# Altium schematic sheet Main.SchDoc
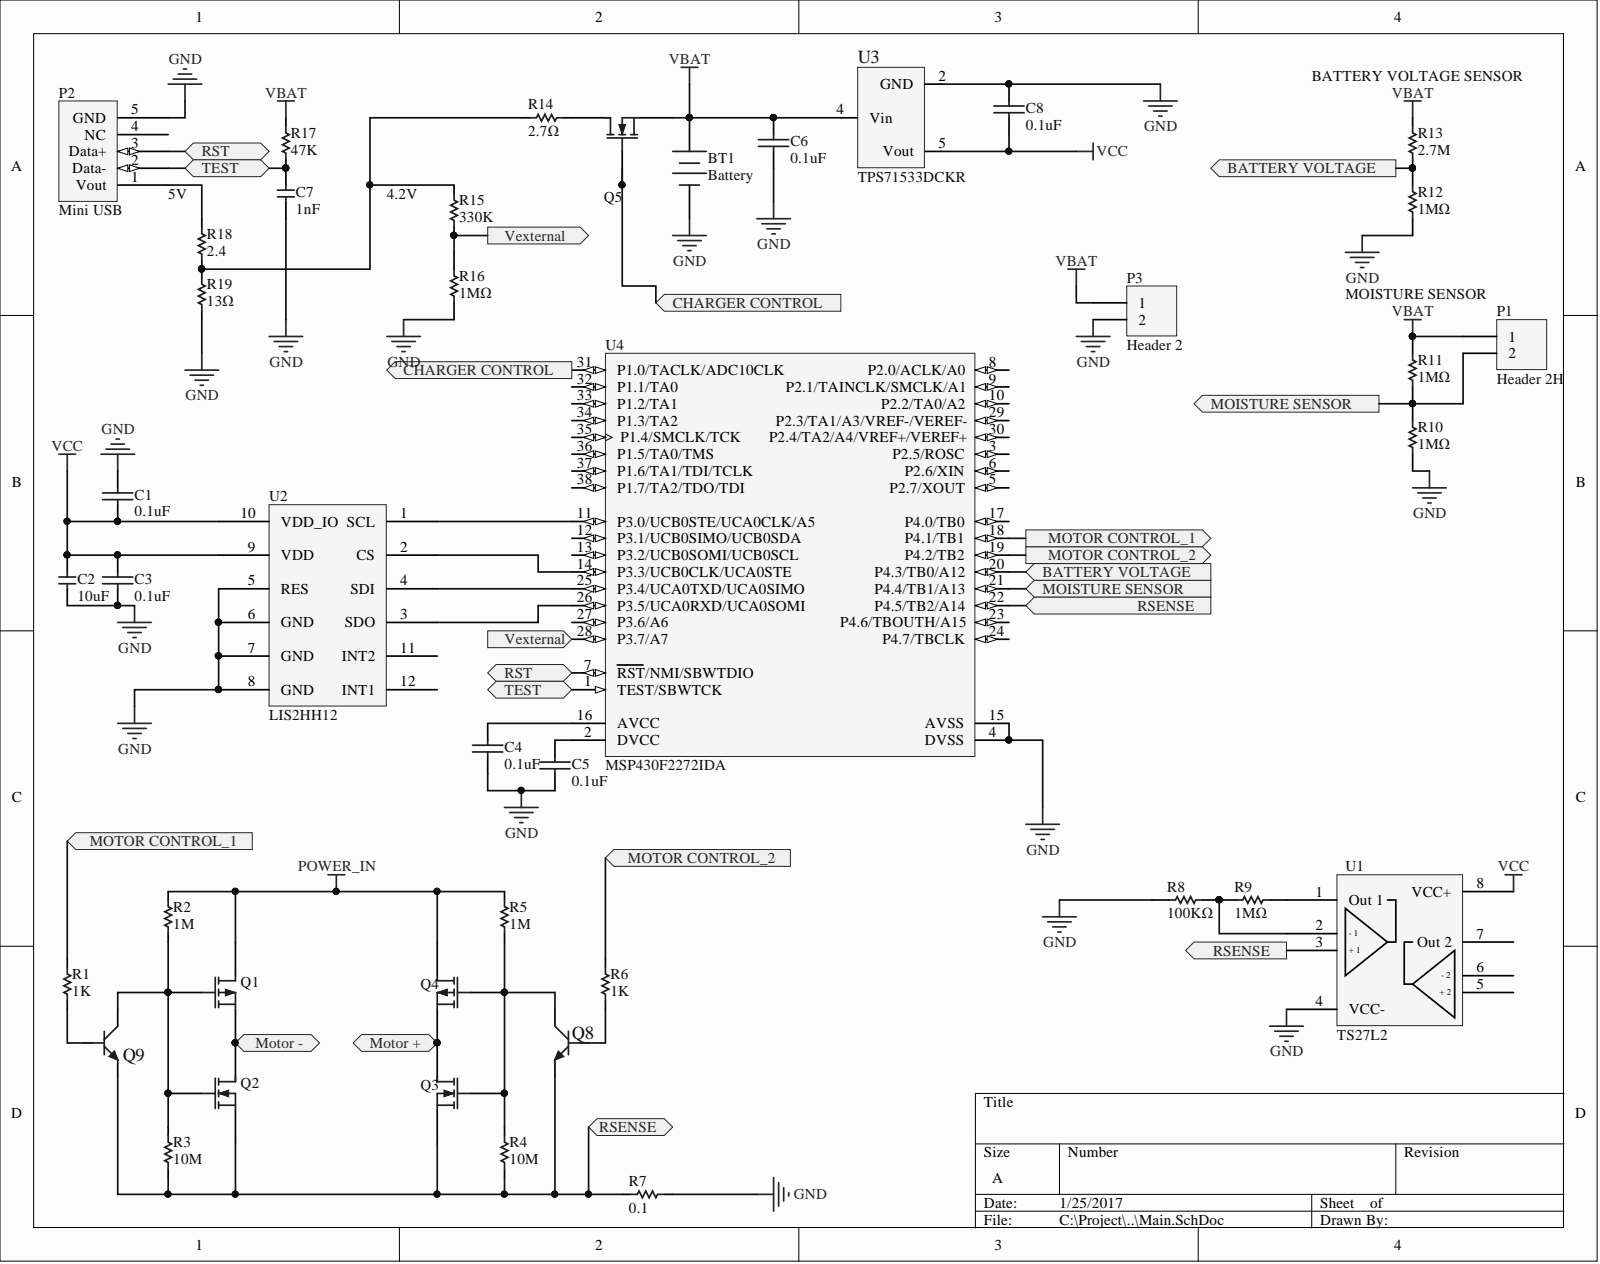
[diagram p0: Main.SchDoc]

## Parts
BT1  Battery
C1  0.1uF  [0603 Small]
C2  10uF  [0805 Small]
C3  0.1uF  [0603 Small]
C4  0.1uF  [0603 Small]
C5  0.1uF  [0603 Small]
C6  0.1uF  [0603 Small]
C7  1nF  [0402 Small]
C8  0.1uF  [0603 Small]
P1  Header 2H  [HDR1X2H]
P2  Mini USB  [Mini USB]
P3  Header 2  [BH123A]
Q1  MOSFET-P  [SOT23]  (value not drawn)
Q2  MOSFET-N  [SOT23]  (value not drawn)
Q3  MOSFET-N  [SOT23]  (value not drawn)
Q4  MOSFET-P  [SOT23]  (value not drawn)
Q5  MOSFET-N  [SOT23]  (value not drawn)
Q8  MMST2222A  [SOT-23N]  (value not drawn)
Q9  MMST2222A  [SOT-23N]  (value not drawn)
R1  1K  [0603 resistor]
R2  1M  [0603 resistor]
R3  10M  [0603 resistor]
R4  10M  [0603 resistor]
R5  1M  [0603 resistor]
R6  1K  [0603 resistor]
R7  0.1  [0603 resistor]
R8  100KΩ  [0603 Resistor]
R9  1MΩ  [0603 Resistor]
R10  1MΩ  [0603 Resistor]
R11  1MΩ  [0603 Resistor]
R12  1MΩ  [0603 Resistor]
R13  2.7M  [0402 Small]
R14  2.7Ω  [2010 resistor]
R15  330K  [0402 Resistor]
R16  1MΩ  [0603 Resistor]
R17  47K  [0402 Resistor]
R18  2.4  [0805 Small]
R19  13Ω  [2512 RESISTOR]
U1  TS27L2  [SOIC-8]
U2  LIS2HH12  [LIS2HH12]
U3  TPS71533DCKR  [SC70_5]
U4  MSP430F2272IDA  [MSP430F2272IDA]

## Ports
BATTERY VOLTAGE, CHARGER CONTROL, MOISTURE SENSOR, MOTOR +, MOTOR -, MOTOR CONTROL_1, MOTOR CONTROL_2, RSENSE, RST, TEST, VEXTERNAL

## Nets
GND: BT1.2 C1.1 C2.1 C3.2 C4.2 C5.2 C6.2 C7.1 C8.1 P2.5(GND) P3.2 R7.1 R8.1 R10.1 R12.1 R16.1 R19.1 U1.4(VCC-) U2.5(RES) U2.6(GND) U2.7(GND) U2.8(GND) U3.2 U4.4(DVSS) U4.15(AVSS)
POWER_IN: Q1.1 Q4.1 R2.1 R5.1
VBAT: BT1.1 C6.1 P1.1 P3.1 Q5.3 R11.2 R13.1 R17.1 U3.4
VCC: C1.2 C2.2 C3.1 C8.2 U1.8(VCC+) U2.9(VDD) U2.10(VDD_IO) U3.5
BATTERY VOLTAGE: R12.2 R13.2 U4.20(P4.3/TB0/A12) ; port BATTERY VOLTAGE
CHARGER CONTROL: Q5.2 U4.31(P1.0/TACLK/ADC10CLK) ; port CHARGER CONTROL
MOISTURE SENSOR: P1.2 R10.2 R11.1 U4.21(P4.4/TB1/A13) ; port MOISTURE SENSOR
MOTOR CONTROL_1: R1.2 U4.18(P4.1/TB1) ; port MOTOR CONTROL_1
MOTOR CONTROL_2: R6.2 U4.19(P4.2/TB2) ; port MOTOR CONTROL_2
RSENSE: Q2.3 Q3.3 Q8.E Q9.E R3.1 R4.1 R7.2 U1.3(+_1) U4.22(P4.5/TB2/A14) ; port RSENSE
RST: P2.3(Data+) U4.7(RST/NMI/SBWTDIO) ; port RST
TEST: C7.2 P2.2(Data-) R17.2 U4.1(TEST/SBWTCK) ; port TEST
NetC4_1: C4.1 U4.16(AVCC)
NetC5_1: C5.1 U4.2(DVCC)
NetP2_1: P2.1(Vout) R18.2
NetQ1_2: Q1.2 Q2.2 Q9.C R2.2 R3.2
NetQ1_3: Q1.3 Q2.1
NetQ3_1: Q3.1 Q4.3
NetQ3_2: Q3.2 Q4.2 Q8.C R4.2 R5.2
NetQ5_1: Q5.1 R14.1
NetQ8_B: Q8.B R6.1
NetQ9_B: Q9.B R1.1
NetR8_2: R8.2 R9.2 U1.2(-_1)
NetR9_1: R9.1 U1.1(Out_1)
NetR14_2: R14.2 R15.1 R18.1 R19.2
NetR15_2: R15.2 R16.2 U4.28(P3.7/A7) ; port VEXTERNAL
NetU2_1: U2.1(SCL) U4.11(P3.0/UCB0STE/UCA0CLK/A5)
NetU2_2: U2.2(CS) U4.14(P3.3/UCB0CLK/UCA0STE)
NetU2_3: U2.3(SDO) U4.26(P3.5/UCA0RXD/UCA0SOMI)
NetU2_4: U2.4(SDI) U4.25(P3.4/UCA0TXD/UCA0SIMO)

Not connected: P2 4(NC); U1 5(+_2) 6(-_2) 7(Out_2); U2 11(INT2) 12(INT1); U4 3(P2.5/ROSC) 5(P2.7/XOUT) 6(P2.6/XIN) 8(P2.0/ACLK/A0) 9(P2.1/TAINCLK/SMCLK/A1) 10(P2.2/TA0/A2) 12(P3.1/UCB0SIMO/UCB0SDA) 13(P3.2/UCB0SOMI/UCB0SCL) 17(P4.0/TB0) 23(P4.6/TBOUTH/A15) 24(P4.7/TBCLK) 27(P3.6/A6) 29(P2.3/TA1/A3/VREF-/VEREF-) 30(P2.4/TA2/A4/VREF+/VEREF+) 32(P1.1/TA0) 33(P1.2/TA1) 34(P1.3/TA2) 35(P1.4/SMCLK/TCK) 36(P1.5/TA0/TMS) 37(P1.6/TA1/TDI/TCLK) 38(P1.7/TA2/TDO/TDI)

## Library data of the parts
BT1 = Battery: Multicell Battery; Manufacture: Olight; Manufacturer#: ORB2-163P06; Component Kind: Standard; Cost: 7.5; LatestRevisionDate: 17-Jul-2002; LatestRevisionNote: Re-released for DXP Platform.; Library Name: Miscellaneous Devices.IntLib; PackageDescription: Battery; 2 Leads; PackageReference: BAT-2; Published: 24-Mar-1999; Publisher: Altium Limited
C1 C3-C6 C8 = CAP: CAP CER 0.1UF 50V 10% X7R 0603; Manufacturer: Murata Electronics; Manufacturer#: GRM188R71H104KA93J; Component Kind: Standard; Cost: 0.022; Library Name: sch protel.SCHLIB
C2 = Cap: CAP CER 10UF 6.3V X5R 0805; Manufacturer: Samsung Electro-Mechanical; Manufacturer#: CL21A106KQCLRNC; Component Kind: Standard; Cost: .01526; Signal Integrity: Cap; Simulation: CAP; Library Name: Curtis.SchLib
C7 = Cap: CAP CER 1000PF 6.3V X5R 0402; Manufacturer: Murata Electronics; Manufacturer#: GRM155R60J102KA01D; Component Kind: Standard; Cost: .0024; Signal Integrity: Cap; Simulation: CAP; Library Name: Curtis.SchLib
P1 = Header 2H: Header, 2-Pin, Right Angle; Component Kind: Standard; LatestRevisionDate: 17-Jul-2002; LatestRevisionNote: Re-released for DXP Platform.; Library Name: Miscellaneous Connectors.IntLib; Signal Integrity: Connector; Publisher: Altium Limited
P2 = Mini USB: CONN MINI USB RCPT RA TYPE B SMD; Manufacturer: EDAC Inc.; Manufacturer#: 690-005-299-043; Component Kind: Standard; Cost: 0.28050; Library Name: Curtis.SchLib
P3 = Header 2: CR123A Battery Holder; Manufacturer: Memory Protection Devices Inc; Component Kind: Standard; Cost: 0.65; Library Name: Miscellaneous Connectors.IntLib; Manufacturer#: BH123A
Q1 Q4 = MOSFET-P: MOSFET P-CH 20V 4.2A SOT23; Manufacturer: Diodes Incorporated; Manufacturer#: DMG2305UX-13; Component Kind: Standard; Cost: 0.0738; Library Name: Miscellaneous Devices.IntLib
Q2 Q3 Q5 = MOSFET-N: MOSFET N-CH 20V 2.3A SOT23; Manufacturer: Infineon Technologies; Manufacturer#: BSS806N H6327; Component Kind: Standard; Cost: 0.07392; Library Name: Miscellaneous Devices.IntLib
Q8 Q9 = NPN1: TRANSISTOR NPN GP 40V SOT23; Manufacturer: MICRO COMMERCIAL CO; Manufacturer#: MMBT2222A-TP; Component Kind: Standard; Cost: 0.0278; Library Name: Curtis.SchLib
R1 R6 = Resistor: RES SMD 1K OHM 5% 1/10W 060; Manufacturer: Yageo; Manufacturer#: RC0603JR-071KL; Component Kind: Standard; Cost: 0.0018; Library Name: Curtis.SchLib
R2 R5 = Resistor: RES SMD 1M OHM 5% 1/10W 0603; Manufacturer: Yageo; Manufacturer#: RC0603JR-071ML; Component Kind: Standard; Cost: 0.0018; Library Name: Curtis.SchLib
R3 R4 = Resistor: RES SMD 10M OHM 5% 1/10W 0603; Manufacturer: Yageo; Manufacturer#: RC0603JR-0710ML; Component Kind: Standard; Cost: 0.0018; Library Name: Curtis.SchLib
R7 = Resistor: RES SMD 0.1 OHM 5% 2W 2512; Manufacturer: Bourns Inc.; Manufacturer#: CRM2512-JX-R100ELF; Component Kind: Standard; Cost: .10395; Library Name: Curtis.SchLib
R8 = Res1: RES SMD 100K OHM 5% 1/10W 0603; Manufacturer: Yageo; Manufacturer#: RC0603JR-07100KL; Component Kind: Standard; Cost: 0.00198; Library Name: Miscellaneous Devices.IntLib; Signal Integrity: Res; Simulation: RESISTOR
R9-R12 R16 = Res1: RES SMD 1M OHM 5% 1/10W 0603; Manufacturer: Yageo; Manufacturer#: RC0603JR-071ML; Component Kind: Standard; Cost: .00198; Library Name: Miscellaneous Devices.IntLib; Signal Integrity: Res; Simulation: RESISTOR
R13 = Resistor: RES SMD 2.7M OHM 5% 1/16W 0402; Manufacturer: Yageo; Manufacturer#: RC0402JR-072M7L; Component Kind: Standard; Cost: 0.00172; Library Name: Curtis.SchLib
R14 = Resistor: RES SMD 2.7 OHM 5% 3/4W 2010; Manufacturer: Panasonic Electronic Components; Manufacturer#: ERJ-12ZYJ2R7U; Component Kind: Standard; Cost: 0.03544; Library Name: Curtis.SchLib
R15 = Resistor: RES SMD 330K OHM 5% 1/10W 0603; Manufacturer: Yageo; Manufacturer#: RC0603JR-07330KL; Component Kind: Standard; Cost: 0.0018; Library Name: Curtis.SchLib
R17 = Resistor: RES SMD 47K OHM 5% 1/16W 0402; Manufacturer: Samsung Electro-Mechanics; Manufacturer#: RC1005J473CS; Component Kind: Standard; Cost: .00188; Library Name: Curtis.SchLib
R18 = Resistor: RES SMD 2 OHM 5% 0.4W 0805; Manufacturer: Rohm Semiconductor; Manufacturer#: ESR10EZPJ2R4; Component Kind: Standard; Cost: 0.01331; Library Name: Curtis.SchLib
R19 = Resistor: RES SMD 13 OHM 1% 2W 2512; Manufacturer: TE Connectivity Passive Product; Manufacturer#: 352113RFT; Component Kind: Standard; Cost: 0.12187; Library Name: Curtis.SchLib
U1 = TS27L2: OP AMP - 2 CHANNEL; Manufacturer: STMicroelectronics; Manufacturer#: TS27L2CDT; Component Kind: Standard; Cost: .1842; Library Name: Curtis.SchLib
U2 = LIS2HH12: Manufacturer: STMicro; Component Kind: Standard; Cost: .486; Manufacturer#: LIS2HH12; Library Name: Curtis.SchLib
U3 = TPS71533DCKR: IC REG LDO 3.3V 50MA SC70-5; Manufacturer: Texas Instruments; Component Kind: Standard; Cost: 0.3952; Manufacturer#: TPS71533DCKR; Library Name: Curtis.SchLib
U4 = CMP-0365-00081-2: IC MCU 16BIT 32KB FLASH 38TSSOP; Manufacturer: Texas Instruments; Manufacturer#: MSP430F2272IDAR; ADC: 10-bit SAR; ADC Channels: 12; Brown Out Reset: Yes; Eco-Plan: Green (RoHS & no Sb/Br); Flash(KB): 32; Frequency(MHz): 16; SRAM(B): 1024; SubFamily: 2 Series, Extended Temp; Timers - 16-bit: 2; USCI_A (UART/LIN/IrDA/SPI): 1; Code_JEDEC: MO-153; Component Kind: Standard; ComponentLink1Description: Manufacturer URL; ComponentLink1URL: http://www.ti.com/; ComponentLink2Description: Datasheet; ComponentLink2URL: http://www.ti.com/general/docs/lit/getliterature.tsp?genericPartNumber=MSP430F2272&fileType=pdf; ComponentLink3Description: Package Specification; ComponentLink3URL: http://www.ti.com/lit/ml/mtss002e/mtss002e.pdf; Cost: 2.25; DatasheetVersion: SLAS504G; GPIO: 32; Mounting Technology: Surface Mount; PackageDescription: 38-Pin Plastic Small-Outline Package, Body 6.10 x 12.50 mm, Pitch 0.65 mm; PackageReference: DA0038A; PackageVersion: 4040066/F; PartNumber: MSP430F2272IDA; USCI_B (I2C & SPI): 1; Watchdog: Yes
